# Altium schematic sheet AFE.SchDoc
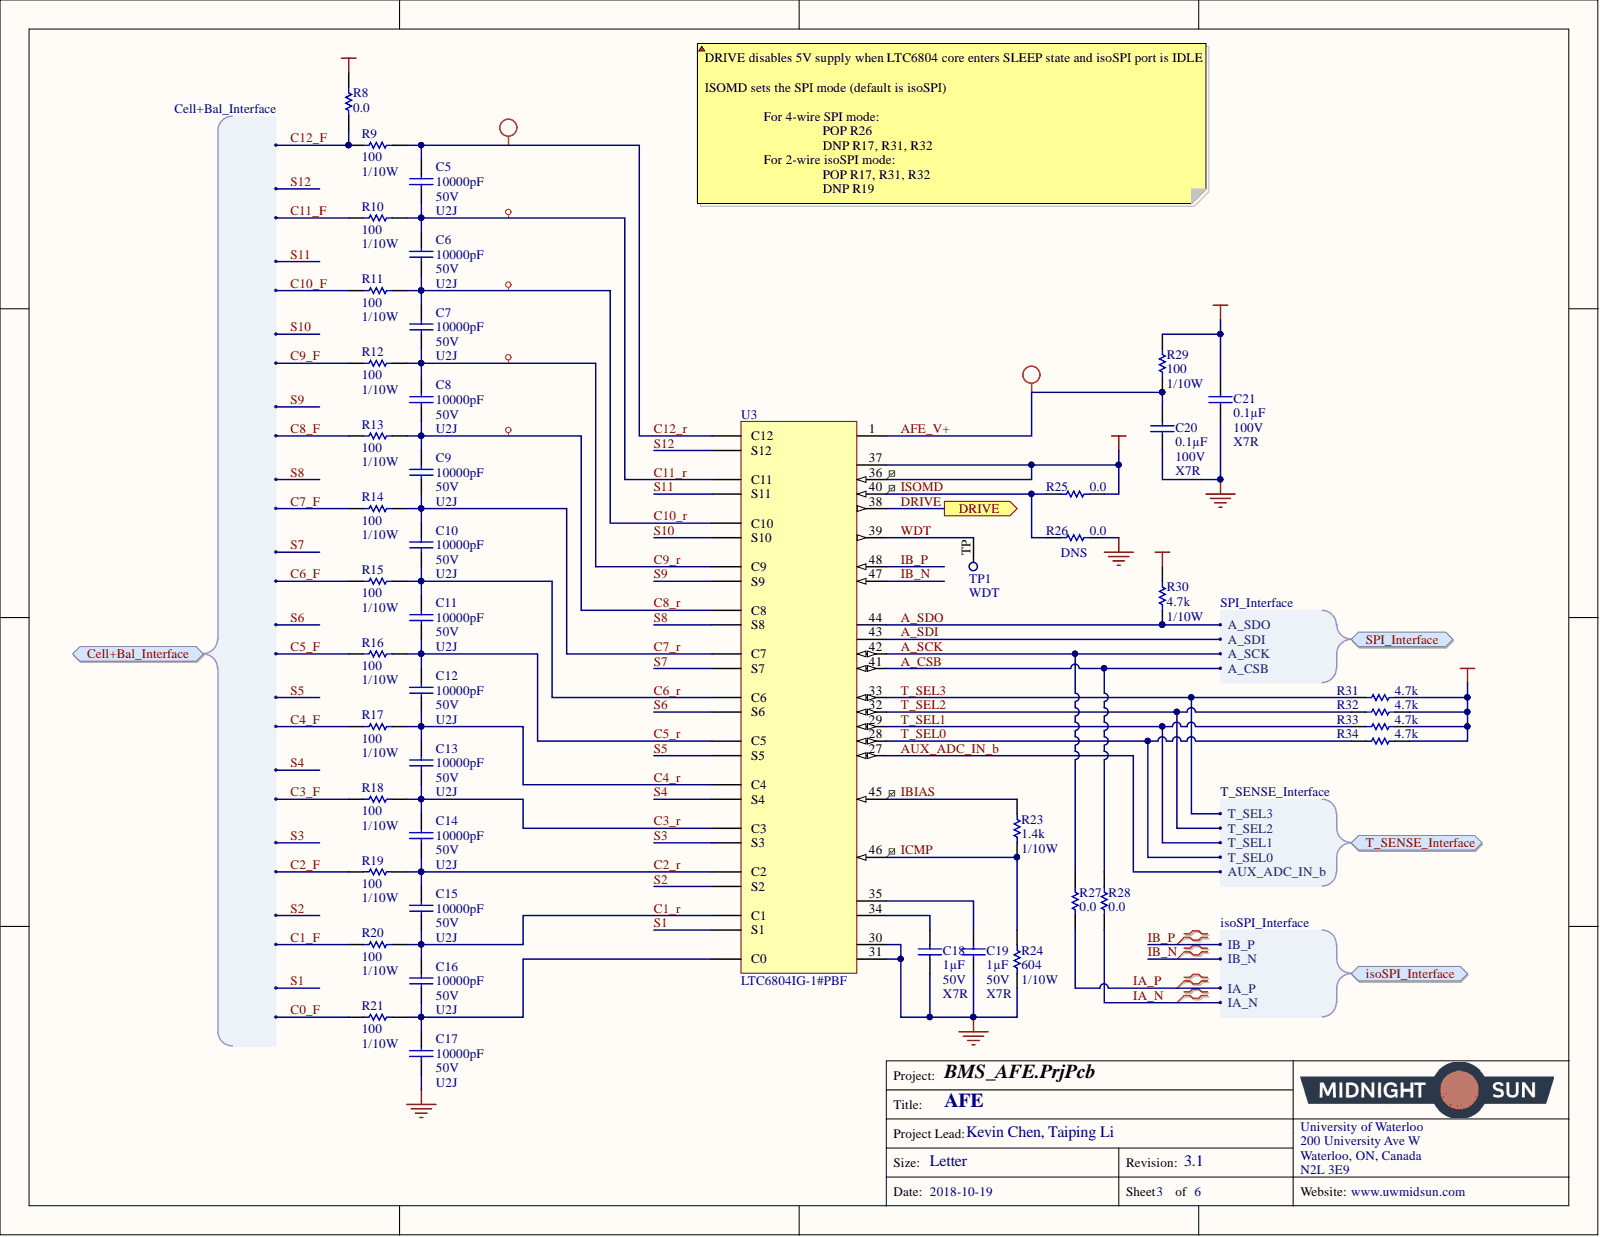
[diagram p0: AFE.SchDoc]

## Parts
C5  Capacitance: 10000pF  Temperature Coefficient: U2J  Voltage: 50V  [CAP, 0603]  (hidden comment: CAP CER 10nF 50V 5% X7R 0603)
C6  Capacitance: 10000pF  Temperature Coefficient: U2J  Voltage: 50V  [CAP, 0603]  (hidden comment: CAP CER 10nF 50V 5% X7R 0603)
C7  Capacitance: 10000pF  Temperature Coefficient: U2J  Voltage: 50V  [CAP, 0603]  (hidden comment: CAP CER 10nF 50V 5% X7R 0603)
C8  Capacitance: 10000pF  Temperature Coefficient: U2J  Voltage: 50V  [CAP, 0603]  (hidden comment: CAP CER 10nF 50V 5% X7R 0603)
C9  Capacitance: 10000pF  Temperature Coefficient: U2J  Voltage: 50V  [CAP, 0603]  (hidden comment: CAP CER 10nF 50V 5% X7R 0603)
C10  Capacitance: 10000pF  Temperature Coefficient: U2J  Voltage: 50V  [CAP, 0603]  (hidden comment: CAP CER 10nF 50V 5% X7R 0603)
C11  Capacitance: 10000pF  Temperature Coefficient: U2J  Voltage: 50V  [CAP, 0603]  (hidden comment: CAP CER 10nF 50V 5% X7R 0603)
C12  Capacitance: 10000pF  Temperature Coefficient: U2J  Voltage: 50V  [CAP, 0603]  (hidden comment: CAP CER 10nF 50V 5% X7R 0603)
C13  Capacitance: 10000pF  Temperature Coefficient: U2J  Voltage: 50V  [CAP, 0603]  (hidden comment: CAP CER 10nF 50V 5% X7R 0603)
C14  Capacitance: 10000pF  Temperature Coefficient: U2J  Voltage: 50V  [CAP, 0603]  (hidden comment: CAP CER 10nF 50V 5% X7R 0603)
C15  Capacitance: 10000pF  Temperature Coefficient: U2J  Voltage: 50V  [CAP, 0603]  (hidden comment: CAP CER 10nF 50V 5% X7R 0603)
C16  Capacitance: 10000pF  Temperature Coefficient: U2J  Voltage: 50V  [CAP, 0603]  (hidden comment: CAP CER 10nF 50V 5% X7R 0603)
C17  Capacitance: 10000pF  Temperature Coefficient: U2J  Voltage: 50V  [CAP, 0603]  (hidden comment: CAP CER 10nF 50V 5% X7R 0603)
C18  Capacitance: 1µF  Temperature Coefficient: X7R  Voltage: 50V  [CAP, 0603]  (hidden comment: CAP CER 1UF 50V 10% X7R 0603)
C19  Capacitance: 1µF  Temperature Coefficient: X7R  Voltage: 50V  [CAP, 0603]  (hidden comment: CAP CER 1UF 50V 10% X7R 0603)
C20  Capacitance: 0.1µF  Temperature Coefficient: X7R  Voltage: 100V  [CAP, 0805]  (hidden comment: CAP CER 0.1UF 100V 10% X7R 0805)
C21  Capacitance: 0.1µF  Temperature Coefficient: X7R  Voltage: 100V  [CAP, 0805]  (hidden comment: CAP CER 0.1UF 100V 10% X7R 0805)
R8  Resistance: 0.0  [RES, 0603]  (hidden comment: RES 0.0 OHM 1/4W 0603)
R9  RES 100 OHM 1% 1/10W 0603  [RES, 0603]  (value not drawn)
R10  RES 100 OHM 1% 1/10W 0603  [RES, 0603]  (value not drawn)
R11  RES 100 OHM 1% 1/10W 0603  [RES, 0603]  (value not drawn)
R12  RES 100 OHM 1% 1/10W 0603  [RES, 0603]  (value not drawn)
R13  RES 100 OHM 1% 1/10W 0603  [RES, 0603]  (value not drawn)
R14  RES 100 OHM 1% 1/10W 0603  [RES, 0603]  (value not drawn)
R15  RES 100 OHM 1% 1/10W 0603  [RES, 0603]  (value not drawn)
R16  RES 100 OHM 1% 1/10W 0603  [RES, 0603]  (value not drawn)
R17  RES 100 OHM 1% 1/10W 0603  [RES, 0603]  (value not drawn)
R18  RES 100 OHM 1% 1/10W 0603  [RES, 0603]  (value not drawn)
R19  RES 100 OHM 1% 1/10W 0603  [RES, 0603]  (value not drawn)
R20  RES 100 OHM 1% 1/10W 0603  [RES, 0603]  (value not drawn)
R21  RES 100 OHM 1% 1/10W 0603  [RES, 0603]  (value not drawn)
R23  Power: 1/10W  Resistance: 1.4k  [RES, 0603]  (hidden comment: RES 1.4k OHM 1% 1/10W 0603)
R24  Power: 1/10W  [RES, 0603]  (hidden comment: RES 604 OHM 1% 1/10W 0603)
R25  Resistance: 0.0  [RES, 0603]  (hidden comment: RES 0.0 OHM 1/4W 0603)
R26  Resistance: 0.0  [RES, 0603]  (hidden comment: RES 0.0 OHM 1/4W 0603)  (no BOM)
R27  Resistance: 0.0  [RES, 0603]  (hidden comment: RES 0.0 OHM 1/4W 0603)
R28  Resistance: 0.0  [RES, 0603]  (hidden comment: RES 0.0 OHM 1/4W 0603)
R29  Power: 1/10W  [RES, 0603]  (hidden comment: RES 100 OHM 1% 1/10W 0603)
R30  Power (Watts): 1/10W  Resistance (Ohms): 4.7k  [RES, 0603]  (hidden comment: RES 4.7K OHM 1% 1/10W 0603)
R31  Resistance (Ohms): 4.7k  [RES, 0603]  (hidden comment: RES 4.7K OHM 1% 1/10W 0603)
R32  Resistance (Ohms): 4.7k  [RES, 0603]  (hidden comment: RES 4.7K OHM 1% 1/10W 0603)
R33  Resistance (Ohms): 4.7k  [RES, 0603]  (hidden comment: RES 4.7K OHM 1% 1/10W 0603)
R34  Resistance (Ohms): 4.7k  [RES, 0603]  (hidden comment: RES 4.7K OHM 1% 1/10W 0603)
TP1  WDT  [Test Point]
U3  Manufacturer Part Number 1: LTC6804IG-1#PBF  [SSOP50P780X200-48N]  (hidden comment: IC MONITOR BATT STACK 48SSOP)

## Ports
Cell+Bal_Interface, Cell+Bal_Interface.C0_F, Cell+Bal_Interface.C1_F, Cell+Bal_Interface.C2_F, Cell+Bal_Interface.C3_F, Cell+Bal_Interface.C4_F, Cell+Bal_Interface.C5_F, Cell+Bal_Interface.C6_F, Cell+Bal_Interface.C7_F, Cell+Bal_Interface.C8_F, Cell+Bal_Interface.C9_F, Cell+Bal_Interface.C10_F, Cell+Bal_Interface.C11_F, Cell+Bal_Interface.C12_F, Cell+Bal_Interface.S1, Cell+Bal_Interface.S2, Cell+Bal_Interface.S3, Cell+Bal_Interface.S4, Cell+Bal_Interface.S5, Cell+Bal_Interface.S6, Cell+Bal_Interface.S7, Cell+Bal_Interface.S8, Cell+Bal_Interface.S9, Cell+Bal_Interface.S10, Cell+Bal_Interface.S11, Cell+Bal_Interface.S12, DRIVE, isoSPI_Interface, isoSPI_Interface.IA_N, isoSPI_Interface.IA_P, isoSPI_Interface.IB_N, isoSPI_Interface.IB_P, SPI_Interface, SPI_Interface.A_CSB, SPI_Interface.A_SCK, SPI_Interface.A_SDI, SPI_Interface.A_SDO, T_SENSE_Interface, T_SENSE_Interface.AUX_ADC_IN_b, T_SENSE_Interface.T_SEL0, T_SENSE_Interface.T_SEL1, T_SENSE_Interface.T_SEL2, T_SENSE_Interface.T_SEL3

## Nets
+5V: R25.2 R30.1 R31.2 R32.2 R33.2 R34.2 U3.36 U3.37
+VTOP: C21.1 R8.1 R29.1
GND: C17.2 C18.2 C19.2 C20.2 C21.2 R24.2 R26.2 U3.30 U3.31
A_CSB: R28.1 U3.41 ; port SPI_Interface
A_SCK: R27.1 U3.42 ; port SPI_Interface
A_SDI: U3.43 ; port SPI_Interface
A_SDO: R30.2 U3.44 ; port SPI_Interface
AFE_V+: C20.1 R29.2 U3.1
AUX_ADC_IN_b: U3.27 ; port T_SENSE_Interface
C0_F: R21.1 ; port Cell+Bal_Interface
C1_F: R20.1 ; port Cell+Bal_Interface
C1_r: C15.2 C16.1 R20.2 U3.24(C1)
C2_F: R19.1 ; port Cell+Bal_Interface
C2_r: C14.2 C15.1 R19.2 U3.22(C2)
C3_F: R18.1 ; port Cell+Bal_Interface
C3_r: C13.2 C14.1 R18.2 U3.20(C3)
C4_F: R17.1 ; port Cell+Bal_Interface
C4_r: C12.2 C13.1 R17.2 U3.18(C4)
C5_F: R16.1 ; port Cell+Bal_Interface
C5_r: C11.2 C12.1 R16.2 U3.16(C5)
C6_F: R15.1 ; port Cell+Bal_Interface
C6_r: C10.2 C11.1 R15.2 U3.14(C6)
C7_F: R14.1 ; port Cell+Bal_Interface
C7_r: C9.2 C10.1 R14.2 U3.12(C7)
C8_F: R13.1 ; port Cell+Bal_Interface
C8_r: C8.2 C9.1 R13.2 U3.10(C8)
C9_F: R12.1 ; port Cell+Bal_Interface
C9_r: C7.2 C8.1 R12.2 U3.8(C9)
C10_F: R11.1 ; port Cell+Bal_Interface
C10_r: C6.2 C7.1 R11.2 U3.6(C10)
C11_F: R10.1 ; port Cell+Bal_Interface
C11_r: C5.2 C6.1 R10.2 U3.4(C11)
C12_F: R8.2 R9.1 ; port Cell+Bal_Interface
C12_r: C5.1 R9.2 U3.2(C12)
DRIVE: U3.38 ; port DRIVE
IA_N: R28.2 ; port isoSPI_Interface
IA_P: R27.2 ; port isoSPI_Interface
IB_N: U3.47 ; port isoSPI_Interface
IB_P: U3.48 ; port isoSPI_Interface
IBIAS: R23.1 U3.45
ICMP: R23.2 R24.1 U3.46
ISOMD: R25.1 R26.1 U3.40
S1: U3.25(S1) ; port Cell+Bal_Interface
S2: U3.23(S2) ; port Cell+Bal_Interface
S3: U3.21(S3) ; port Cell+Bal_Interface
S4: U3.19(S4) ; port Cell+Bal_Interface
S5: U3.17(S5) ; port Cell+Bal_Interface
S6: U3.15(S6) ; port Cell+Bal_Interface
S7: U3.13(S7) ; port Cell+Bal_Interface
S8: U3.11(S8) ; port Cell+Bal_Interface
S9: U3.9(S9) ; port Cell+Bal_Interface
S10: U3.7(S10) ; port Cell+Bal_Interface
S11: U3.5(S11) ; port Cell+Bal_Interface
S12: U3.3(S12) ; port Cell+Bal_Interface
T_SEL0: R34.1 U3.28 ; port T_SENSE_Interface
T_SEL1: R33.1 U3.29 ; port T_SENSE_Interface
T_SEL2: R32.1 U3.32 ; port T_SENSE_Interface
T_SEL3: R31.1 U3.33 ; port T_SENSE_Interface
WDT: TP1.TP U3.39
NetC16_2: C16.2 C17.1 R21.2 U3.26(C0)
NetC18_1: C18.1 U3.34
NetC19_1: C19.1 U3.35

## Library data of the parts
C5-C17 = CAP CER 10nF 50V 5% X7R 0603: 10000pF ±5% 50V Ceramic Capacitor U2J 0603 (1608 Metric); Manufacturer 1: KEMET; Manufacturer Part Number 1: C0603C103J5JAC7867; Component Kind: Standard; ComponentLink1Description: http://www.kemet.com/docfinder?Partnumber=C0603C103J5JAC7867; ComponentLink1URL: http://www.kemet.com/docfinder?Partnumber=C0603C103J5JAC7867; Failure Rate: -; Height - Seated (Max): -; Lead Spacing: -; Lead Style: -; Mounting Type: Surface Mount, MLCC; Operating Temperature: -55°C ~ 125°C; Package / Case: 0603 (1608 Metric); Packaging: Cut Tape (CT); Part Status: Active; Ratings: -; Size / Dimension: 0.063" L x 0.032" W (1.60mm x 0.80mm); Supplier 1: Digi-Key; Supplier Part Number 1: 399-13384-1-ND; Thickness (Max): 0.034" (0.87mm); Tolerance: ±5%; Library Name: Schematic Diagrams.SchLib
C18 C19 = CAP CER 1UF 50V 10% X7R 0603: 1µF ±10% 50V Ceramic Capacitor X7R 0603 (1608 Metric); Manufacturer 1: Taiyo Yuden; Manufacturer Part Number 1: UMK107AB7105KA-T; Component Kind: Standard; ComponentLink1Description: http://www.yuden.co.jp/productdata/catalog/en/mlcc_all_e.pdf; ComponentLink1URL: http://www.yuden.co.jp/productdata/catalog/en/mlcc_all_e.pdf; Height - Seated (Max): -; Lead Spacing: -; Lead Style: -; Mounting Type: Surface Mount, MLCC; Operating Temperature: -55°C ~ 125°C; Package / Case: 0603 (1608 Metric); Packaging: Cut Tape (CT); Part Status: Active; Ratings: -; Size / Dimension: 0.063" L x 0.031" W (1.60mm x 0.80mm); Supplier 1: Digi-Key; Supplier Part Number 1: 587-3247-1-ND; Thickness (Max): 0.037" (0.95mm); Tolerance: ±10%; Library Name: Schematic Diagrams.SchLib
C20 C21 = CAP CER 0.1UF 100V 10% X7R 0805: 0.1µF ±10% 100V Ceramic Capacitor X7R 0805 (2012 Metric); Manufacturer 1: Murata Electronics North America; Manufacturer Part Number 1: GCM21BR72A104KA37L; Component Kind: Standard; ComponentLink1Description: http://www.murata.com/~/media/webrenewal/support/library/catalog/products/capacitor/mlcc/c03e.ashx; ComponentLink1URL: http://www.murata.com/~/media/webrenewal/support/library/catalog/products/capacitor/mlcc/c03e.ashx; Height - Seated (Max): -; Lead Spacing: -; Lead Style: -; Mounting Type: Surface Mount, MLCC; Operating Temperature: -55°C ~ 125°C; Package / Case: 0805 (2012 Metric); Packaging: Cut Tape (CT); Part Status: Active; Ratings: AEC-Q200; Size / Dimension: 0.079" L x 0.049" W (2.00mm x 1.25mm); Supplier 1: Digi-Key; Supplier Part Number 1: 490-4789-1-ND; Thickness (Max): 0.055" (1.40mm); Tolerance: ±10%; Library Name: Schematic Diagrams.SchLib
R8 R25-R28 = RES 0.0 OHM 1/4W 0603: RES SMD 0.0 OHM JUMPER 1/4W 0603; Manufacturer 1: Vishay Dale; Manufacturer Part Number 1: CRCW06030000Z0EAHP; ComponentLink1Description: http://www.vishay.com/docs/20043/crcwhpe3.pdf; ComponentLink1URL: http://www.vishay.com/docs/20043/crcwhpe3.pdf; Composition: Thick Film; Failure Rate: -; Height - Seated (Max): 0.022" (0.55mm); Operating Temperature: -55°C ~ 155°C; Package / Case: 0603 (1608 Metric); Packaging: Cut Tape (CT); Part Status: Active; Power (Watts): 1/4W; Size / Dimension: 0.063" L x 0.033" W (1.60mm x 0.85mm); Supplier 1: Digi-Key; Supplier Device Package: 0603; Supplier Part Number 1: 541-0.0SBCT-ND; Library Name: Schematic Diagrams.SchLib
  R8 R25 R27 R28: Component Kind: Standard
  R26: DNS: DNS
R9-R21 R29 = RES 100 OHM 1% 1/10W 0603: 100 Ohm ±1% 0.1W, 1/10W Chip Resistor 0603 (1608 Metric) Moisture Resistant Thick Film; Manufacturer 1: Yageo; Manufacturer Part Number 1: RC0603FR-07100RL; Resistance: 100; Temperature Coefficient: ±100ppm/°C; Component Kind: Standard; ComponentLink1Description: http://www.yageo.com/documents/recent/PYu-RC_Group_51_RoHS_L_7.pdf; ComponentLink1URL: http://www.yageo.com/documents/recent/PYu-RC_Group_51_RoHS_L_7.pdf; Composition: Thick Film; Failure Rate: -; Height - Seated (Max): 0.022" (0.55mm); Operating Temperature: -55°C ~ 155°C; Package / Case: 0603 (1608 Metric); Packaging: Cut Tape (CT); Part Status: Active; Size / Dimension: 0.063" L x 0.031" W (1.60mm x 0.80mm); Supplier 1: Digi-Key; Supplier Device Package: 0603; Supplier Part Number 1: 311-100HRCT-ND; Tolerance: ±1%; Library Name: Schematic Diagrams.SchLib
  R9-R21: Power: 1/10W
R23 = RES 1.4k OHM 1% 1/10W 0603: RES SMD 1.4K OHM 1% 1/10W 0603; Manufacturer 1: Yageo; Manufacturer Part Number 1: RC0603FR-071K4L; Temperature Coefficient: ±100ppm/°C; Component Kind: Standard; ComponentLink1Description: http://www.yageo.com/documents/recent/PYu-RC_Group_51_RoHS_L_7.pdf; ComponentLink1URL: http://www.yageo.com/documents/recent/PYu-RC_Group_51_RoHS_L_7.pdf; Composition: Thick Film; Failure Rate: -; Height - Seated (Max): 0.022" (0.55mm); Operating Temperature: -55°C ~ 155°C; Package / Case: 0603 (1608 Metric); Packaging: Cut Tape (CT); Part Status: Active; Size / Dimension: 0.063" L x 0.031" W (1.60mm x 0.80mm); Supplier 1: Digi-Key; Supplier Device Package: 0603; Supplier Part Number 1: 311-1.40KHRCT-ND; Tolerance: ±1%; Library Name: Schematic Diagrams.SchLib
R24 = RES 604 OHM 1% 1/10W 0603: RES SMD 604 OHM 1% 1/10W 0603; Manufacturer 1: Yageo; Manufacturer Part Number 1: RC0603FR-07604RL; Resistance: 604; Temperature Coefficient: ±100ppm/°C; Component Kind: Standard; ComponentLink1Description: http://www.yageo.com/documents/recent/PYu-RC_Group_51_RoHS_L_7.pdf; ComponentLink1URL: http://www.yageo.com/documents/recent/PYu-RC_Group_51_RoHS_L_7.pdf; Composition: Thick Film; Failure Rate: -; Height - Seated (Max): 0.022" (0.55mm); Operating Temperature: -55°C ~ 155°C; Package / Case: 0603 (1608 Metric); Packaging: Cut Tape (CT); Part Status: Active; Size / Dimension: 0.063" L x 0.031" W (1.60mm x 0.80mm); Supplier 1: Digi-Key; Supplier Device Package: 0603; Supplier Part Number 1: 311-604HRCT-ND; Tolerance: ±1%; Library Name: Schematic Diagrams.SchLib
R30-R34 = RES 4.7K OHM 1% 1/10W 0603: 4.7k Ohm ±1% 0.1W, 1/10W Chip Resistor 0603 (1608 Metric) Moisture Resistant Thick Film; Manufacturer 1: Yageo; Manufacturer Part Number 1: RC0603FR-074K7L; Description 1: RES SMD 4.7K OHM 1% 1/10W 0603; Temperature Coefficient: ±100ppm/°C; Category: Resistors; Component Kind: Standard; ComponentLink1Description: http://www.yageo.com/documents/recent/PYu-RC_Group_51_RoHS_L_7.pdf; ComponentLink1URL: http://www.yageo.com/documents/recent/PYu-RC_Group_51_RoHS_L_7.pdf; Composition: Thick Film; Failure Rate: -; Features: Moisture Resistant; Height - Seated (Max): 0.022" (0.55mm); Operating Temperature: -55°C ~ 155°C; Package / Case: 0603 (1608 Metric); Packaging: Cut Tape (CT); Part Status: Active; Pricing 1: 1=0.16, 10=0.022, 100=0.0089, 1000=0.00402, 2500=0.00349 (CAD); RoHS: RoHS Compliant; Size / Dimension: 0.063" L x 0.031" W (1.60mm x 0.80mm); Stock 1: 3487566; Supplier 1: Digi-Key; Supplier Device Package: 0603; Supplier Part Number 1: 311-4.70KHRCT-ND; Tolerance: ±1%; Library Name: Schematic Diagrams.SchLib
  R31-R34: Power (Watts): 1/10W
TP1 = Test Point: Component Kind: Standard; Library Name: Schematic Diagrams.SchLib
U3 = IC MONITOR BATT STACK 48SSOP: Battery Battery Monitor IC Multi-Chemistry 48-SSOP; Manufacturer 1: Linear Technology; Fault Protection: Over/Under Voltage; Interface: I²C, SPI; Number of Cells: 1 ~ 12; Battery Chemistry: Multi-Chemistry; Component Kind: Standard; ComponentLink1Description: http://www.linear.com/docs/43171; ComponentLink1URL: http://www.linear.com/docs/43171; Function: Battery Monitor; Operating Temperature: -40°C ~ 85°C (TA); Package / Case: 48-FSOP (0.209", 5.30mm Width); Packaging: Tube; Part Status: Active; Supplier 1: Digi-Key; Supplier Device Package: 48-SSOP; Supplier Part Number 1: LTC6804IG-1#PBF-ND; Library Name: Schematic Diagrams.SchLib
